# Altium schematic sheet DLDVI_T221_ADAPTER.SchDoc(DLDVI_T221_ADAPTER)
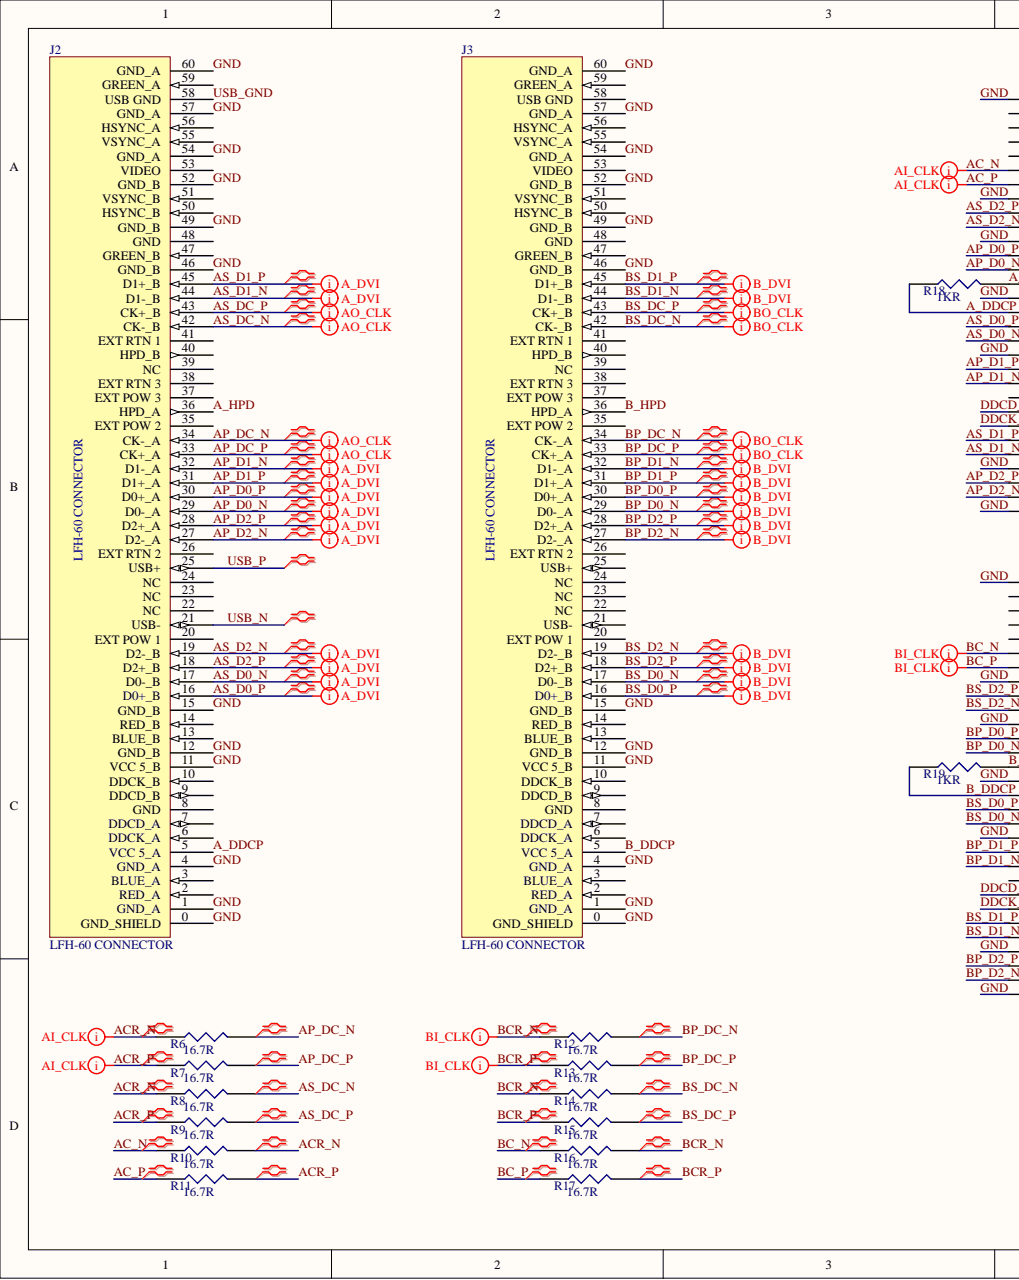
[diagram p0: DLDVI_T221_ADAPTER.SchDoc, left]
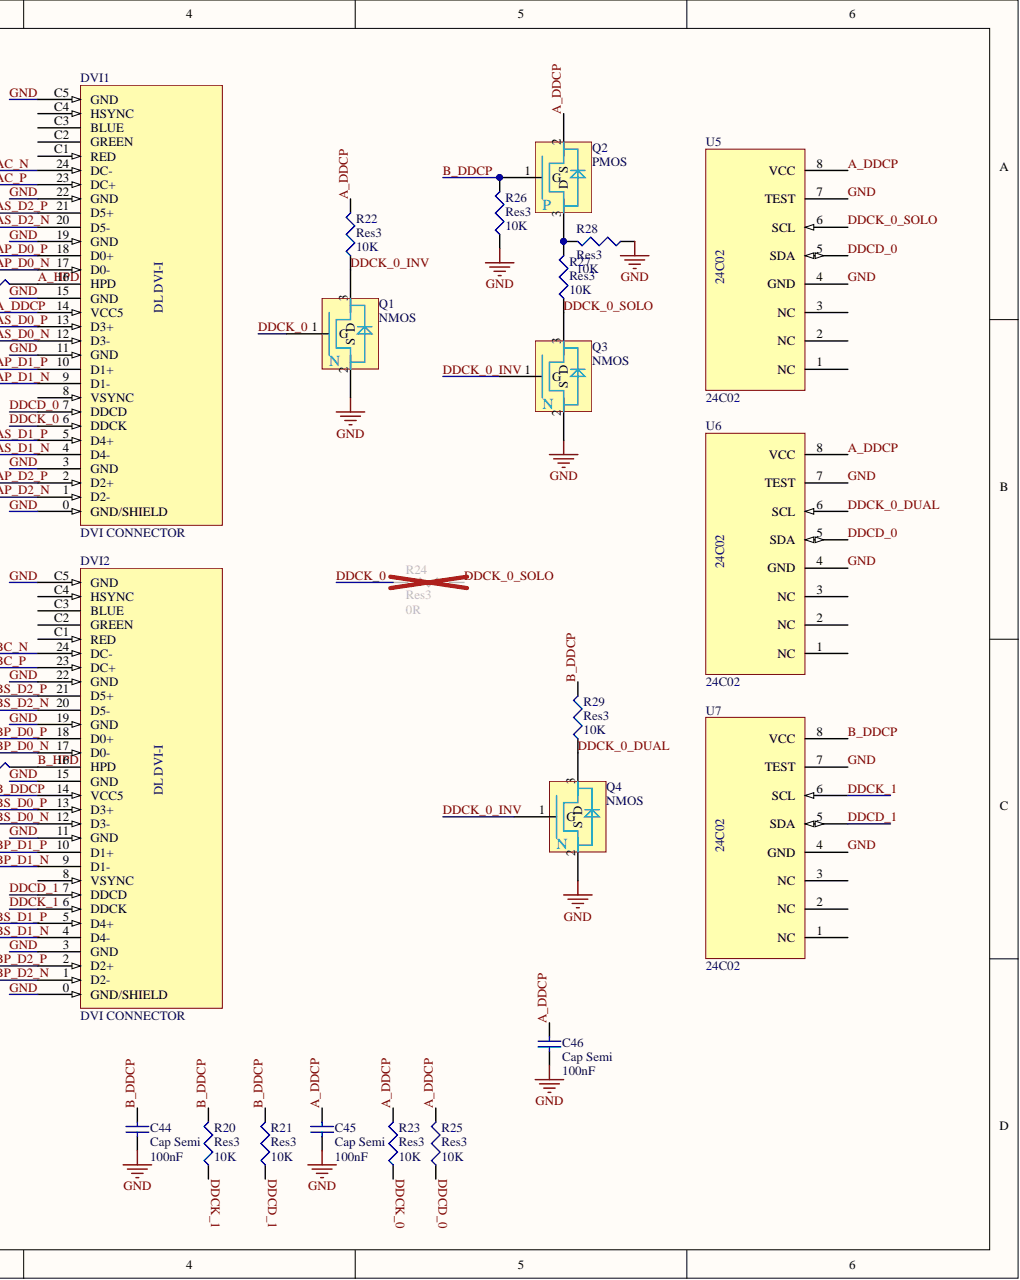
[diagram p1: DLDVI_T221_ADAPTER.SchDoc, right]

## Parts
C44  Cap Semi  value 100nF  [SMD_0402_CAP]
C45  Cap Semi  value 100nF  [SMD_0402_CAP]
C46  Cap Semi  value 100nF  [SMD_0402_CAP]
DVI1  DVI CONNECTOR  [DVI-I CONNECTOR]
DVI2  DVI CONNECTOR  [DVI-I CONNECTOR]
J2  LFH-60 CONNECTOR  [LFH-60]
J3  LFH-60 CONNECTOR  [LFH-60]
Q1  NMOS  [SOT323]
Q2  PMOS  [SOT323]
Q3  NMOS  [SOT323]
Q4  NMOS  [SOT323]
R6  16.7R  [SMD_0402_RES]
R7  16.7R  [SMD_0402_RES]
R8  16.7R  [SMD_0402_RES]
R9  16.7R  [SMD_0402_RES]
R10  16.7R  [SMD_0402_RES]
R11  16.7R  [SMD_0402_RES]
R12  16.7R  [SMD_0402_RES]
R13  16.7R  [SMD_0402_RES]
R14  16.7R  [SMD_0402_RES]
R15  16.7R  [SMD_0402_RES]
R16  16.7R  [SMD_0402_RES]
R17  16.7R  [SMD_0402_RES]
R18  1KR  [SMD_0402_RES]
R19  1KR  [SMD_0402_RES]
R20  Res3  value 10K  [SMD_0402_RES]
R21  Res3  value 10K  [SMD_0402_RES]
R22  Res3  value 10K  [SMD_0402_RES]
R23  Res3  value 10K  [SMD_0402_RES]
R24  Res3  value 0R  [SMD_0402_RES]
R25  Res3  value 10K  [SMD_0402_RES]
R26  Res3  value 10K  [SMD_0402_RES]
R27  Res3  value 10K  [SMD_0402_RES]
R28  Res3  value 10K  [SMD_0402_RES]
R29  Res3  value 10K  [SMD_0402_RES]
U5  24C02  [SOIC8 + MSOP8 inline]
U6  24C02  [SOIC8 + MSOP8 inline]
U7  24C02  [SOIC8 + MSOP8 inline]

## Nets
A_DDCP: C45.2 C46.2 DVI1.14(VCC5) J2.5(VCC_5_A) Q2.2 R18.1 R22.2 R23.2 R25.2 U5.8(VCC) U6.8(VCC)
A_HPD: DVI1.16(HPD) J2.36(HPD_A) R18.2
AC_N: DVI1.24(DC-) R10.1
AC_P: DVI1.23(DC+) R11.1
ACR_N: R6.1 R8.1 R10.2
ACR_P: R7.1 R9.1 R11.2
AP_D0_N: DVI1.17(D0-) J2.29(D0-_A)
AP_D0_P: DVI1.18(D0+) J2.30(D0+_A)
AP_D1_N: DVI1.9(D1-) J2.32(D1-_A)
AP_D1_P: DVI1.10(D1+) J2.31(D1+_A)
AP_D2_N: DVI1.1(D2-) J2.27(D2-_A)
AP_D2_P: DVI1.2(D2+) J2.28(D2+_A)
AP_DC_N: J2.34(CK-_A) R6.2
AP_DC_P: J2.33(CK+_A) R7.2
AS_D0_N: DVI1.12(D3-) J2.17(D0-_B)
AS_D0_P: DVI1.13(D3+) J2.16(D0+_B)
AS_D1_N: DVI1.4(D4-) J2.44(D1-_B)
AS_D1_P: DVI1.5(D4+) J2.45(D1+_B)
AS_D2_N: DVI1.20(D5-) J2.19(D2-_B)
AS_D2_P: DVI1.21(D5+) J2.18(D2+_B)
AS_DC_N: J2.42(CK-_B) R8.2
AS_DC_P: J2.43(CK+_B) R9.2
B_DDCP: C44.2 DVI2.14(VCC5) J3.5(VCC_5_A) Q2.1 R19.1 R20.2 R21.2 R26.2 R29.2 U7.8(VCC)
B_HPD: DVI2.16(HPD) J3.36(HPD_A) R19.2
BC_N: DVI2.24(DC-) R16.1
BC_P: DVI2.23(DC+) R17.1
BCR_N: R12.1 R14.1 R16.2
BCR_P: R13.1 R15.1 R17.2
BP_D0_N: DVI2.17(D0-) J3.29(D0-_A)
BP_D0_P: DVI2.18(D0+) J3.30(D0+_A)
BP_D1_N: DVI2.9(D1-) J3.32(D1-_A)
BP_D1_P: DVI2.10(D1+) J3.31(D1+_A)
BP_D2_N: DVI2.1(D2-) J3.27(D2-_A)
BP_D2_P: DVI2.2(D2+) J3.28(D2+_A)
BP_DC_N: J3.34(CK-_A) R12.2
BP_DC_P: J3.33(CK+_A) R13.2
BS_D0_N: DVI2.12(D3-) J3.17(D0-_B)
BS_D0_P: DVI2.13(D3+) J3.16(D0+_B)
BS_D1_N: DVI2.4(D4-) J3.44(D1-_B)
BS_D1_P: DVI2.5(D4+) J3.45(D1+_B)
BS_D2_N: DVI2.20(D5-) J3.19(D2-_B)
BS_D2_P: DVI2.21(D5+) J3.18(D2+_B)
BS_DC_N: J3.42(CK-_B) R14.2
BS_DC_P: J3.43(CK+_B) R15.2
DDCD_0: DVI1.7(DDCD) R25.1 U5.5(SDA) U6.5(SDA)
DDCD_1: DVI2.7(DDCD) R21.1 U7.5(SDA)
DDCK_0: DVI1.6(DDCK) Q1.1 R23.1 R24.2
DDCK_0_DUAL: Q4.3 R29.1 U6.6(SCL)
DDCK_0_INV: Q1.3 Q3.1 Q4.1 R22.1
DDCK_0_SOLO: Q3.3 R24.1 R27.1 U5.6(SCL)
DDCK_1: DVI2.6(DDCK) R20.1 U7.6(SCL)
GND: C44.1 C45.1 C46.1 DVI1.0(GND/SHIELD) DVI1.3(GND) DVI1.11(GND) DVI1.15(GND) DVI1.19(GND) DVI1.22(GND) DVI1.C5(GND) DVI2.0(GND/SHIELD) DVI2.3(GND) DVI2.11(GND) DVI2.15(GND) DVI2.19(GND) DVI2.22(GND) DVI2.C5(GND) J2.0(GND_SHIELD) J2.1(GND_A) J2.4(GND_A) J2.11(VCC_5_B) J2.12(GND_B) J2.15(GND_B) J2.46(GND_B) J2.49(GND_B) J2.52(GND_B) J2.54(GND_A) J2.57(GND_A) J2.60(GND_A) J3.0(GND_SHIELD) J3.1(GND_A) J3.4(GND_A) J3.11(VCC_5_B) J3.12(GND_B) J3.15(GND_B) J3.46(GND_B) J3.49(GND_B) J3.52(GND_B) J3.54(GND_A) J3.57(GND_A) J3.60(GND_A) Q1.2 Q3.2 Q4.2 R26.1 R28.1 U5.4(GND) U5.7(TEST) U6.4(GND) U6.7(TEST) U7.4(GND) U7.7(TEST)
USB_GND: J2.58(USB_GND)
USB_N: J2.21(USB-)
USB_P: J2.25(USB+)
NetQ2_3: Q2.3 R27.2 R28.2

Not connected: DVI1 8(VSYNC) C1(RED) C2(GREEN) C3(BLUE) C4(HSYNC); DVI2 8(VSYNC) C1(RED) C2(GREEN) C3(BLUE) C4(HSYNC); J2 2(RED_A) 3(BLUE_A) 6(DDCK_A) 7(DDCD_A) 8(GND) 9(DDCD_B) 10(DDCK_B) 13(BLUE_B) 14(RED_B) 20(EXT_POW_1) 22(NC) 23(NC) 24(NC) 26(EXT_RTN_2) 35(EXT_POW_2) 37(EXT_POW_3) 38(EXT_RTN_3) 39(NC) 40(HPD_B) 41(EXT_RTN_1) 47(GREEN_B) 48(GND) 50(HSYNC_B) 51(VSYNC_B) 53(VIDEO) 55(VSYNC_A) 56(HSYNC_A) 59(GREEN_A); J3 2(RED_A) 3(BLUE_A) 6(DDCK_A) 7(DDCD_A) 8(GND) 9(DDCD_B) 10(DDCK_B) 13(BLUE_B) 14(RED_B) 20(EXT_POW_1) 21(USB-) 22(NC) 23(NC) 24(NC) 25(USB+) 26(EXT_RTN_2) 35(EXT_POW_2) 37(EXT_POW_3) 38(EXT_RTN_3) 39(NC) 40(HPD_B) 41(EXT_RTN_1) 47(GREEN_B) 48(GND) 50(HSYNC_B) 51(VSYNC_B) 53(VIDEO) 55(VSYNC_A) 56(HSYNC_A) 58(USB_GND) 59(GREEN_A); U5 1(NC) 2(NC) 3(NC); U6 1(NC) 2(NC) 3(NC); U7 1(NC) 2(NC) 3(NC)

## Library data of the parts
C44-C46 = Cap Semi: Capacitor (Semiconductor SIM Model); Manufacturer: Generic; Notes: Specified voltage is minimum.  May substitute for higher-voltage part.  May substitute with tighter tolerance part.; Substitutable?: YES; Tolerance(%): 10%; Voltage Rating: 10V; Component Kind: Standard; Library Name: Miscellaneous Devices.IntLib; Part: Capacitor; Signal Integrity: Cap Semi; Simulation: CAP; Supplier: Digikey; Supplier Part #: GRM155R62A104ME14D
DVI1 DVI2 = DVI-I CONNECTOR: DVI CONNECTOR WITH ANALOG PINS; Manufacturer: TE Connectivity; Part: 1-1734148-1; Notes: Verify footprint before substituting; Substitutable?: YES; Component Kind: Standard; Library Name: SCH Library.SchLib; Supplier: Digikey; Supplier Part #: 1-1734148-1-ND
J2 J3 = LFH-60 CONNECTOR: Manufacturer: Molex; Part: 0015922250; Substitutable?: NO; Component Kind: Standard; Library Name: SCH Library.SchLib; Supplier: Digikey; Supplier Part #: WM5015-ND
Q1 Q3 Q4 = NMOS: Manufacturer: Fairchild; Part: BSS138k; Current Rating (Continuious): 0.18A; Notes: If substituting, verify threshold voltage compatibility; Substitutable?: YES; Voltage Rating: 60Vds 20Vgs; Component Kind: Standard; Library Name: SCH Library.SchLib; Supplier: Digikey; Supplier Part #: BSS138KCT-ND
Q2 = PMOS: Manufacturer: Fairchild; Part: BSS84; Current Rating (Continuious): 0.13A; Notes: If substituting, verify threshold voltage compatibility; Substitutable?: YES; Voltage Rating: 50Vds 20Vgs; Component Kind: Standard; Library Name: SCH Library.SchLib; Supplier: Digikey; Supplier Part #: BSS84CT-ND
R6-R19 = RES1: Manufacturer: Generic; Part: Resistor; Notes: May substitute with tighter tolerance part.; Power Dissipation(mW): 63; Substitutable?: YES; Tolerance(%): 5%; Component Kind: Standard; Supplier: Digikey
  R6-R17: Supplier Part #: P16.5LCT-ND; Value: 16.7R
  R18 R19: Supplier Part #: P1.00KLCT-ND; Value: 1KR
R20-R27 R29 = Res3: Resistor; Manufacturer: Generic; Notes: May substitute with tighter tolerance part.; Power Dissipation(mW): 63; Substitutable?: YES; Tolerance(%): 5%; Component Kind: Standard; Library Name: Miscellaneous Devices.IntLib; Part: Resistor; Signal Integrity: Res; Simulation: RESISTOR; Supplier: Digikey
  R20-R23 R25-R27 R29: Supplier Part #: P10.0KLCT-ND
  R24: Supplier Part #: P0.0JCT-ND
R28 = Res3: Resistor; Manufacturer: Generic; Notes: May substitute with tighter tolerance part.; Power Dissipation(mW): 63; Substitutable?: YES; Tolerance(%): 5%; Value: 10K; Component Kind: Standard; Library Name: Miscellaneous Devices.IntLib; Part: Resistor; Signal Integrity: Res; Simulation: RESISTOR; Supplier: Digikey; Supplier Part #: P10.0KLCT-ND
U5-U7 = 24C02: Manufacturer: ST Microelectronics; Part: M24C02-FMN6TP; Notes: Other 5V 1K eeproms will work.; Substitutable?: YES; Voltage Rating: 5V; Component Kind: Standard; Library Name: SCH Library.SchLib; Supplier: Digikey; Supplier Part #: 497-15748-1-ND
